# Altium schematic sheet SCH002 - VSC742x power supply & decoupling.SchDoc
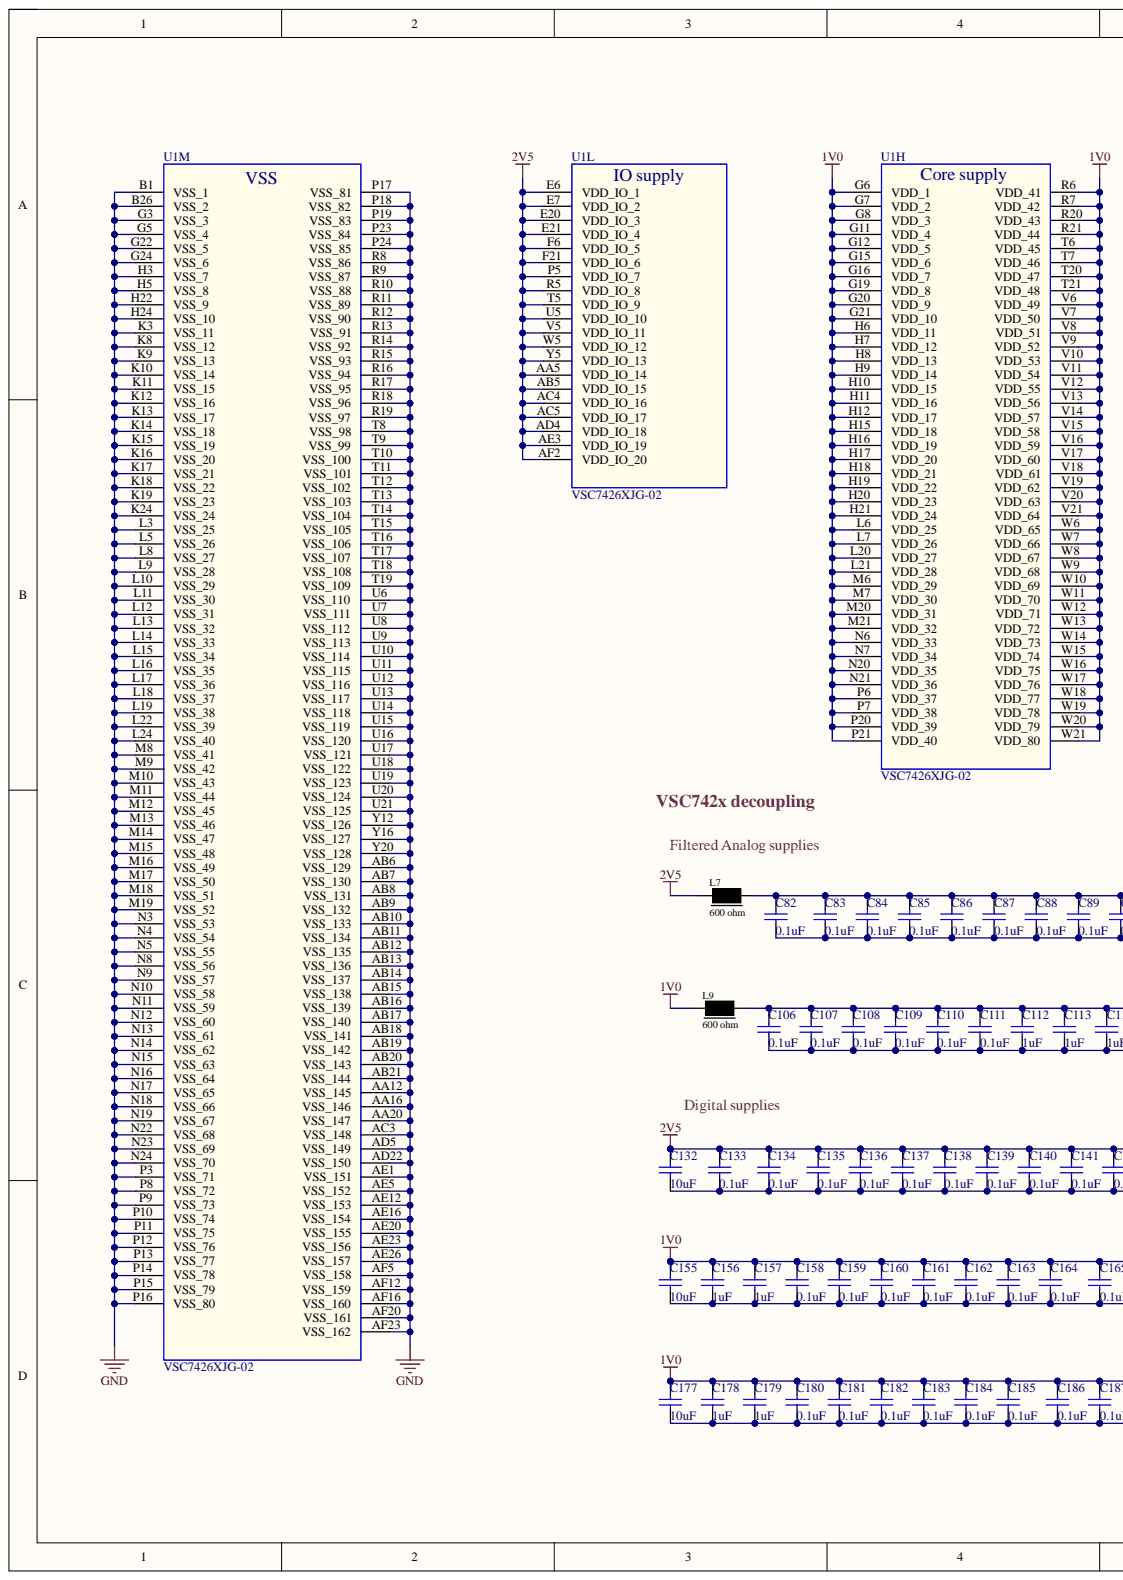
[diagram p0: SCH002 - VSC742x power supply & decoupling.SchDoc, left]
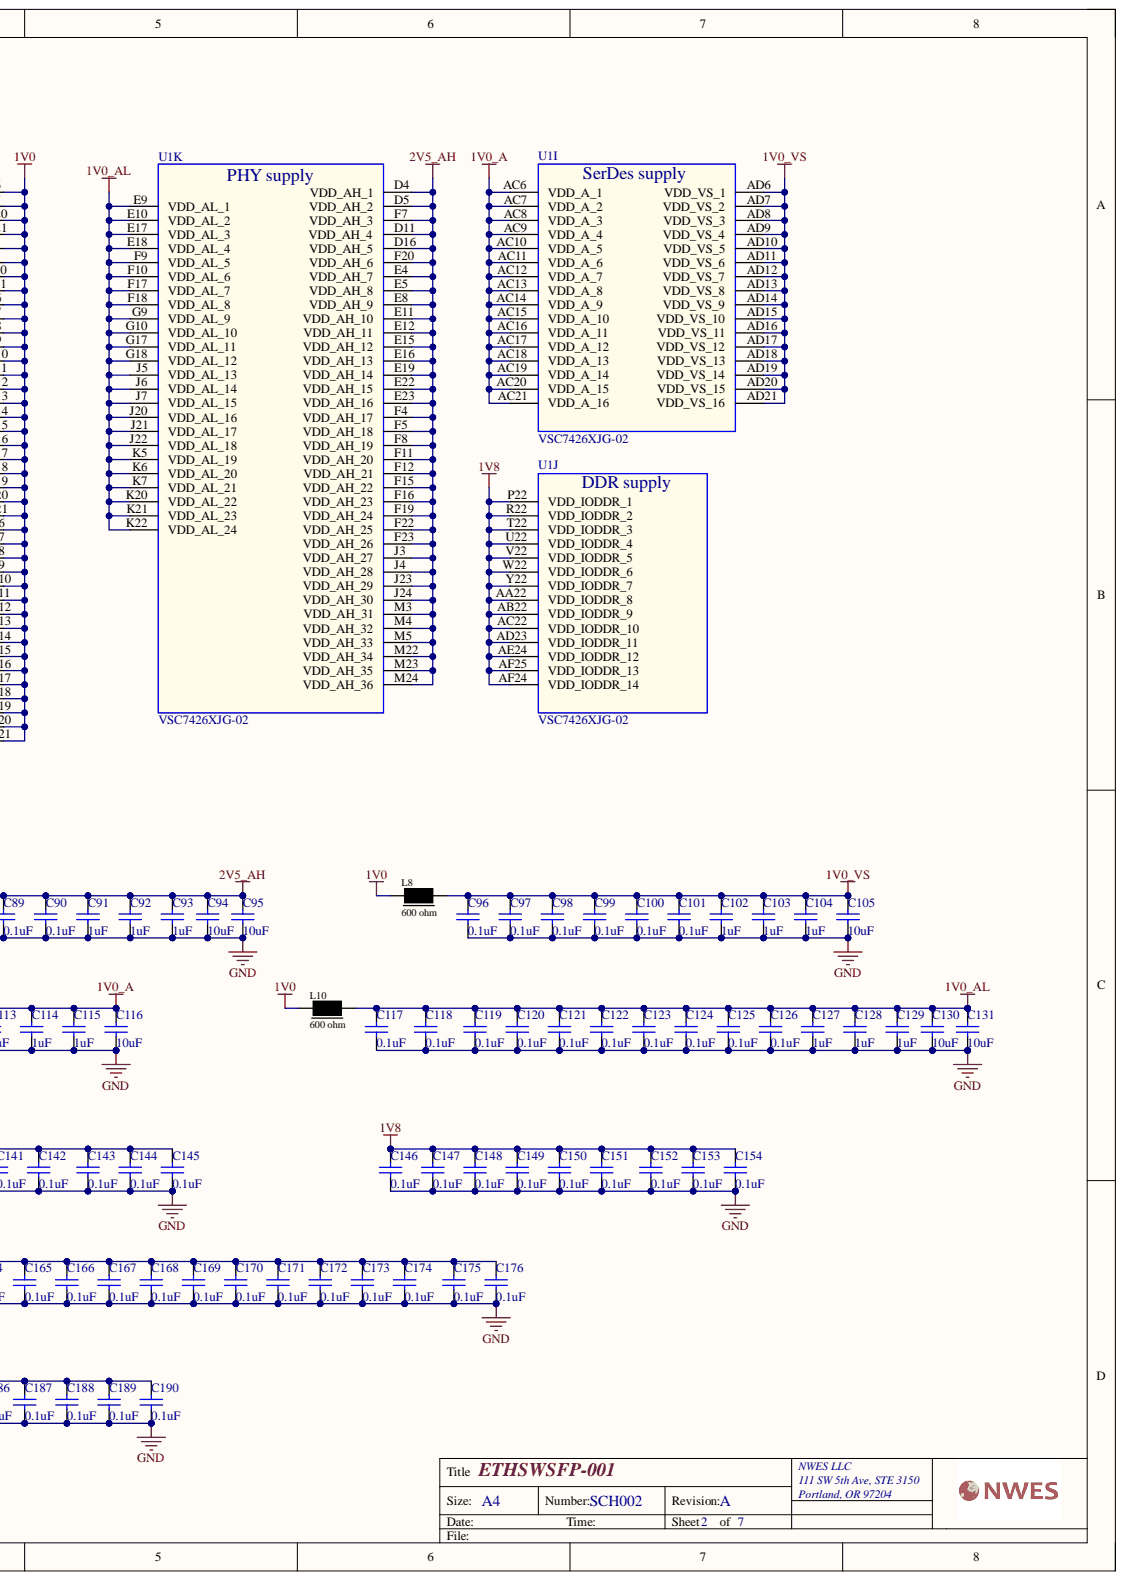
[diagram p1: SCH002 - VSC742x power supply & decoupling.SchDoc, right]

## Parts
C82  0.1uF  [CAPC1005X61USR]
C83  0.1uF  [CAPC1005X61USR]
C84  0.1uF  [CAPC1005X61USR]
C85  0.1uF  [CAPC1005X61USR]
C86  0.1uF  [CAPC1005X61USR]
C87  0.1uF  [CAPC1005X61USR]
C88  0.1uF  [CAPC1005X61USR]
C89  0.1uF  [CAPC1005X61USR]
C90  0.1uF  [CAPC1005X61USR]
C91  1uF  [CAPC1005X61USR]
C92  1uF  [CAPC1005X61USR]
C93  1uF  [CAPC1005X61USR]
C94  10uF  [CAPC1005X61USR]
C95  10uF  [CAPC1005X61USR]
C96  0.1uF  [CAPC1005X61USR]
C97  0.1uF  [CAPC1005X61USR]
C98  0.1uF  [CAPC1005X61USR]
C99  0.1uF  [CAPC1005X61USR]
C100  0.1uF  [CAPC1005X61USR]
C101  0.1uF  [CAPC1005X61USR]
C102  1uF  [CAPC1005X61USR]
C103  1uF  [CAPC1005X61USR]
C104  1uF  [CAPC1005X61USR]
C105  10uF  [CAPC1005X61USR]
C106  0.1uF  [CAPC1005X61USR]
C107  0.1uF  [CAPC1005X61USR]
C108  0.1uF  [CAPC1005X61USR]
C109  0.1uF  [CAPC1005X61USR]
C110  0.1uF  [CAPC1005X61USR]
C111  0.1uF  [CAPC1005X61USR]
C112  1uF  [CAPC1005X61USR]
C113  1uF  [CAPC1005X61USR]
C114  1uF  [CAPC1005X61USR]
C115  1uF  [CAPC1005X61USR]
C116  10uF  [CAPC1005X61USR]
C117  0.1uF  [CAPC1005X61USR]
C118  0.1uF  [CAPC1005X61USR]
C119  0.1uF  [CAPC1005X61USR]
C120  0.1uF  [CAPC1005X61USR]
C121  0.1uF  [CAPC1005X61USR]
C122  0.1uF  [CAPC1005X61USR]
C123  0.1uF  [CAPC1005X61USR]
C124  0.1uF  [CAPC1005X61USR]
C125  0.1uF  [CAPC1005X61USR]
C126  0.1uF  [CAPC1005X61USR]
C127  1uF  [CAPC1005X61USR]
C128  1uF  [CAPC1005X61USR]
C129  1uF  [CAPC1005X61USR]
C130  10uF  [CAPC1005X61USR]
C131  10uF  [CAPC1005X61USR]
C132  10uF  [CAPC1005X61USR]
C133  0.1uF  [CAPC1005X61USR]
C134  0.1uF  [CAPC1005X61USR]
C135  0.1uF  [CAPC1005X61USR]
C136  0.1uF  [CAPC1005X61USR]
C137  0.1uF  [CAPC1005X61USR]
C138  0.1uF  [CAPC1005X61USR]
C139  0.1uF  [CAPC1005X61USR]
C140  0.1uF  [CAPC1005X61USR]
C141  0.1uF  [CAPC1005X61USR]
C142  0.1uF  [CAPC1005X61USR]
C143  0.1uF  [CAPC1005X61USR]
C144  0.1uF  [CAPC1005X61USR]
C145  0.1uF  [CAPC1005X61USR]
C146  0.1uF  [CAPC1005X61USR]
C147  0.1uF  [CAPC1005X61USR]
C148  0.1uF  [CAPC1005X61USR]
C149  0.1uF  [CAPC1005X61USR]
C150  0.1uF  [CAPC1005X61USR]
C151  0.1uF  [CAPC1005X61USR]
C152  0.1uF  [CAPC1005X61USR]
C153  0.1uF  [CAPC1005X61USR]
C154  0.1uF  [CAPC1005X61USR]
C155  10uF  [CAPC1005X61USR]
C156  1uF  [CAPC1005X61USR]
C157  1uF  [CAPC1005X61USR]
C158  0.1uF  [CAPC1005X61USR]
C159  0.1uF  [CAPC1005X61USR]
C160  0.1uF  [CAPC1005X61USR]
C161  0.1uF  [CAPC1005X61USR]
C162  0.1uF  [CAPC1005X61USR]
C163  0.1uF  [CAPC1005X61USR]
C164  0.1uF  [CAPC1005X61USR]
C165  0.1uF  [CAPC1005X61USR]
C166  0.1uF  [CAPC1005X61USR]
C167  0.1uF  [CAPC1005X61USR]
C168  0.1uF  [CAPC1005X61USR]
C169  0.1uF  [CAPC1005X61USR]
C170  0.1uF  [CAPC1005X61USR]
C171  0.1uF  [CAPC1005X61USR]
C172  0.1uF  [CAPC1005X61USR]
C173  0.1uF  [CAPC1005X61USR]
C174  0.1uF  [CAPC1005X61USR]
C175  0.1uF  [CAPC1005X61USR]
C176  0.1uF  [CAPC1005X61USR]
C177  10uF  [CAPC1005X61USR]
C178  1uF  [CAPC1005X61USR]
C179  1uF  [CAPC1005X61USR]
C180  0.1uF  [CAPC1005X61USR]
C181  0.1uF  [CAPC1005X61USR]
C182  0.1uF  [CAPC1005X61USR]
C183  0.1uF  [CAPC1005X61USR]
C184  0.1uF  [CAPC1005X61USR]
C185  0.1uF  [CAPC1005X61USR]
C186  0.1uF  [CAPC1005X61USR]
C187  0.1uF  [CAPC1005X61USR]
C188  0.1uF  [CAPC1005X61USR]
C189  0.1uF  [CAPC1005X61USR]
C190  0.1uF  [CAPC1005X61USR]
L7  600 ohm  [0603_FB]
L8  600 ohm  [0603_FB]
L9  600 ohm  [0603_FB]
L10  600 ohm  [0603_FB]
U1  VSC7426XJG-02  [BGA672C100P26X26_2700X2700X244]  (parts H,I,J,K,L,M)

## Nets
1V0: C155.1 C156.2 C157.2 C158.1 C159.1 C160.1 C161.1 C162.1 C163.1 C164.1 C165.1 C166.1 C167.1 C168.1 C169.1 C170.1 C171.1 C172.1 C173.1 C174.1 C175.1 C176.1 C177.1 C178.2 C179.2 C180.1 C181.1 C182.1 C183.1 C184.1 C185.1 C186.1 C187.1 C188.1 C189.1 C190.1 L8.1 L9.1 L10.1 U1.G6(VDD_1) U1.G7(VDD_2) U1.G8(VDD_3) U1.G11(VDD_4) U1.G12(VDD_5) U1.G15(VDD_6) U1.G16(VDD_7) U1.G19(VDD_8) U1.G20(VDD_9) U1.G21(VDD_10) U1.H6(VDD_11) U1.H7(VDD_12) U1.H8(VDD_13) U1.H9(VDD_14) U1.H10(VDD_15) U1.H11(VDD_16) U1.H12(VDD_17) U1.H15(VDD_18) U1.H16(VDD_19) U1.H17(VDD_20) U1.H18(VDD_21) U1.H19(VDD_22) U1.H20(VDD_23) U1.H21(VDD_24) U1.L6(VDD_25) U1.L7(VDD_26) U1.L20(VDD_27) U1.L21(VDD_28) U1.M6(VDD_29) U1.M7(VDD_30) U1.M20(VDD_31) U1.M21(VDD_32) U1.N6(VDD_33) U1.N7(VDD_34) U1.N20(VDD_35) U1.N21(VDD_36) U1.P6(VDD_37) U1.P7(VDD_38) U1.P20(VDD_39) U1.P21(VDD_40) U1.R6(VDD_41) U1.R7(VDD_42) U1.R20(VDD_43) U1.R21(VDD_44) U1.T6(VDD_45) U1.T7(VDD_46) U1.T20(VDD_47) U1.T21(VDD_48) U1.V6(VDD_49) U1.V7(VDD_50) U1.V8(VDD_51) U1.V9(VDD_52) U1.V10(VDD_53) U1.V11(VDD_54) U1.V12(VDD_55) U1.V13(VDD_56) U1.V14(VDD_57) U1.V15(VDD_58) U1.V16(VDD_59) U1.V17(VDD_60) U1.V18(VDD_61) U1.V19(VDD_62) U1.V20(VDD_63) U1.V21(VDD_64) U1.W6(VDD_65) U1.W7(VDD_66) U1.W8(VDD_67) U1.W9(VDD_68) U1.W10(VDD_69) U1.W11(VDD_70) U1.W12(VDD_71) U1.W13(VDD_72) U1.W14(VDD_73) U1.W15(VDD_74) U1.W16(VDD_75) U1.W17(VDD_76) U1.W18(VDD_77) U1.W19(VDD_78) U1.W20(VDD_79) U1.W21(VDD_80)
1V0_A: C106.1 C107.1 C108.1 C109.1 C110.1 C111.1 C112.2 C113.2 C114.2 C115.2 C116.1 L9.2 U1.AC6(VDD_A_1) U1.AC7(VDD_A_2) U1.AC8(VDD_A_3) U1.AC9(VDD_A_4) U1.AC10(VDD_A_5) U1.AC11(VDD_A_6) U1.AC12(VDD_A_7) U1.AC13(VDD_A_8) U1.AC14(VDD_A_9) U1.AC15(VDD_A_10) U1.AC16(VDD_A_11) U1.AC17(VDD_A_12) U1.AC18(VDD_A_13) U1.AC19(VDD_A_14) U1.AC20(VDD_A_15) U1.AC21(VDD_A_16)
1V0_AL: C117.1 C118.1 C119.1 C120.1 C121.1 C122.1 C123.1 C124.1 C125.1 C126.1 C127.2 C128.2 C129.2 C130.1 C131.1 L10.2 U1.E9(VDD_AL_1) U1.E10(VDD_AL_2) U1.E17(VDD_AL_3) U1.E18(VDD_AL_4) U1.F9(VDD_AL_5) U1.F10(VDD_AL_6) U1.F17(VDD_AL_7) U1.F18(VDD_AL_8) U1.G9(VDD_AL_9) U1.G10(VDD_AL_10) U1.G17(VDD_AL_11) U1.G18(VDD_AL_12) U1.J5(VDD_AL_13) U1.J6(VDD_AL_14) U1.J7(VDD_AL_15) U1.J20(VDD_AL_16) U1.J21(VDD_AL_17) U1.J22(VDD_AL_18) U1.K5(VDD_AL_19) U1.K6(VDD_AL_20) U1.K7(VDD_AL_21) U1.K20(VDD_AL_22) U1.K21(VDD_AL_23) U1.K22(VDD_AL_24)
1V0_VS: C96.1 C97.1 C98.1 C99.1 C100.1 C101.1 C102.2 C103.2 C104.2 C105.1 L8.2 U1.AD6(VDD_VS_1) U1.AD7(VDD_VS_2) U1.AD8(VDD_VS_3) U1.AD9(VDD_VS_4) U1.AD10(VDD_VS_5) U1.AD11(VDD_VS_6) U1.AD12(VDD_VS_7) U1.AD13(VDD_VS_8) U1.AD14(VDD_VS_9) U1.AD15(VDD_VS_10) U1.AD16(VDD_VS_11) U1.AD17(VDD_VS_12) U1.AD18(VDD_VS_13) U1.AD19(VDD_VS_14) U1.AD20(VDD_VS_15) U1.AD21(VDD_VS_16)
1V8: C146.1 C147.1 C148.1 C149.1 C150.1 C151.1 C152.1 C153.1 C154.1 U1.AA22(VDD_IODDR_8) U1.AB22(VDD_IODDR_9) U1.AC22(VDD_IODDR_10) U1.AD23(VDD_IODDR_11) U1.AE24(VDD_IODDR_12) U1.AF24(VDD_IODDR_14) U1.AF25(VDD_IODDR_13) U1.P22(VDD_IODDR_1) U1.R22(VDD_IODDR_2) U1.T22(VDD_IODDR_3) U1.U22(VDD_IODDR_4) U1.V22(VDD_IODDR_5) U1.W22(VDD_IODDR_6) U1.Y22(VDD_IODDR_7)
2V5: C132.1 C133.1 C134.1 C135.1 C136.1 C137.1 C138.1 C139.1 C140.1 C141.1 C142.1 C143.1 C144.1 C145.1 L7.1 U1.AA5(VDD_IO_14) U1.AB5(VDD_IO_15) U1.AC4(VDD_IO_16) U1.AC5(VDD_IO_17) U1.AD4(VDD_IO_18) U1.AE3(VDD_IO_19) U1.AF2(VDD_IO_20) U1.E6(VDD_IO_1) U1.E7(VDD_IO_2) U1.E20(VDD_IO_3) U1.E21(VDD_IO_4) U1.F6(VDD_IO_5) U1.F21(VDD_IO_6) U1.P5(VDD_IO_7) U1.R5(VDD_IO_8) U1.T5(VDD_IO_9) U1.U5(VDD_IO_10) U1.V5(VDD_IO_11) U1.W5(VDD_IO_12) U1.Y5(VDD_IO_13)
2V5_AH: C82.1 C83.1 C84.1 C85.1 C86.1 C87.1 C88.1 C89.1 C90.1 C91.2 C92.2 C93.2 C94.1 C95.1 L7.2 U1.D4(VDD_AH_1) U1.D5(VDD_AH_2) U1.D11(VDD_AH_4) U1.D16(VDD_AH_5) U1.E4(VDD_AH_7) U1.E5(VDD_AH_8) U1.E8(VDD_AH_9) U1.E11(VDD_AH_10) U1.E12(VDD_AH_11) U1.E15(VDD_AH_12) U1.E16(VDD_AH_13) U1.E19(VDD_AH_14) U1.E22(VDD_AH_15) U1.E23(VDD_AH_16) U1.F4(VDD_AH_17) U1.F5(VDD_AH_18) U1.F7(VDD_AH_3) U1.F8(VDD_AH_19) U1.F11(VDD_AH_20) U1.F12(VDD_AH_21) U1.F15(VDD_AH_22) U1.F16(VDD_AH_23) U1.F19(VDD_AH_24) U1.F20(VDD_AH_6) U1.F22(VDD_AH_25) U1.F23(VDD_AH_26) U1.J3(VDD_AH_27) U1.J4(VDD_AH_28) U1.J23(VDD_AH_29) U1.J24(VDD_AH_30) U1.M3(VDD_AH_31) U1.M4(VDD_AH_32) U1.M5(VDD_AH_33) U1.M22(VDD_AH_34) U1.M23(VDD_AH_35) U1.M24(VDD_AH_36)
GND: C82.2 C83.2 C84.2 C85.2 C86.2 C87.2 C88.2 C89.2 C90.2 C91.1 C92.1 C93.1 C94.2 C95.2 C96.2 C97.2 C98.2 C99.2 C100.2 C101.2 C102.1 C103.1 C104.1 C105.2 C106.2 C107.2 C108.2 C109.2 C110.2 C111.2 C112.1 C113.1 C114.1 C115.1 C116.2 C117.2 C118.2 C119.2 C120.2 C121.2 C122.2 C123.2 C124.2 C125.2 C126.2 C127.1 C128.1 C129.1 C130.2 C131.2 C132.2 C133.2 C134.2 C135.2 C136.2 C137.2 C138.2 C139.2 C140.2 C141.2 C142.2 C143.2 C144.2 C145.2 C146.2 C147.2 C148.2 C149.2 C150.2 C151.2 C152.2 C153.2 C154.2 C155.2 C156.1 C157.1 C158.2 C159.2 C160.2 C161.2 C162.2 C163.2 C164.2 C165.2 C166.2 C167.2 C168.2 C169.2 C170.2 C171.2 C172.2 C173.2 C174.2 C175.2 C176.2 C177.2 C178.1 C179.1 C180.2 C181.2 C182.2 C183.2 C184.2 C185.2 C186.2 C187.2 C188.2 C189.2 C190.2 U1.AA12(VSS_145) U1.AA16(VSS_146) U1.AA20(VSS_147) U1.AB6(VSS_129) U1.AB7(VSS_130) U1.AB8(VSS_131) U1.AB9(VSS_132) U1.AB10(VSS_133) U1.AB11(VSS_134) U1.AB12(VSS_135) U1.AB13(VSS_136) U1.AB14(VSS_137) U1.AB15(VSS_138) U1.AB16(VSS_139) U1.AB17(VSS_140) U1.AB18(VSS_141) U1.AB19(VSS_142) U1.AB20(VSS_143) U1.AB21(VSS_144) U1.AC3(VSS_148) U1.AD5(VSS_149) U1.AD22(VSS_150) U1.AE1(VSS_151) U1.AE5(VSS_152) U1.AE12(VSS_153) U1.AE16(VSS_154) U1.AE20(VSS_155) U1.AE23(VSS_156) U1.AE26(VSS_157) U1.AF5(VSS_158) U1.AF12(VSS_159) U1.AF16(VSS_160) U1.AF20(VSS_161) U1.AF23(VSS_162) U1.B1(VSS_1) U1.B26(VSS_2) U1.G3(VSS_3) U1.G5(VSS_4) U1.G22(VSS_5) U1.G24(VSS_6) U1.H3(VSS_7) U1.H5(VSS_8) U1.H22(VSS_9) U1.H24(VSS_10) U1.K3(VSS_11) U1.K8(VSS_12) U1.K9(VSS_13) U1.K10(VSS_14) U1.K11(VSS_15) U1.K12(VSS_16) U1.K13(VSS_17) U1.K14(VSS_18) U1.K15(VSS_19) U1.K16(VSS_20) U1.K17(VSS_21) U1.K18(VSS_22) U1.K19(VSS_23) U1.K24(VSS_24) U1.L3(VSS_25) U1.L5(VSS_26) U1.L8(VSS_27) U1.L9(VSS_28) U1.L10(VSS_29) U1.L11(VSS_30) U1.L12(VSS_31) U1.L13(VSS_32) U1.L14(VSS_33) U1.L15(VSS_34) U1.L16(VSS_35) U1.L17(VSS_36) U1.L18(VSS_37) U1.L19(VSS_38) U1.L22(VSS_39) U1.L24(VSS_40) U1.M8(VSS_41) U1.M9(VSS_42) U1.M10(VSS_43) U1.M11(VSS_44) U1.M12(VSS_45) U1.M13(VSS_46) U1.M14(VSS_47) U1.M15(VSS_48) U1.M16(VSS_49) U1.M17(VSS_50) U1.M18(VSS_51) U1.M19(VSS_52) U1.N3(VSS_53) U1.N4(VSS_54) U1.N5(VSS_55) U1.N8(VSS_56) U1.N9(VSS_57) U1.N10(VSS_58) U1.N11(VSS_59) U1.N12(VSS_60) U1.N13(VSS_61) U1.N14(VSS_62) U1.N15(VSS_63) U1.N16(VSS_64) U1.N17(VSS_65) U1.N18(VSS_66) U1.N19(VSS_67) U1.N22(VSS_68) U1.N23(VSS_69) U1.N24(VSS_70) U1.P3(VSS_71) U1.P8(VSS_72) U1.P9(VSS_73) U1.P10(VSS_74) U1.P11(VSS_75) U1.P12(VSS_76) U1.P13(VSS_77) U1.P14(VSS_78) U1.P15(VSS_79) U1.P16(VSS_80) U1.P17(VSS_81) U1.P18(VSS_82) U1.P19(VSS_83) U1.P23(VSS_84) U1.P24(VSS_85) U1.R8(VSS_86) U1.R9(VSS_87) U1.R10(VSS_88) U1.R11(VSS_89) U1.R12(VSS_90) U1.R13(VSS_91) U1.R14(VSS_92) U1.R15(VSS_93) U1.R16(VSS_94) U1.R17(VSS_95) U1.R18(VSS_96) U1.R19(VSS_97) U1.T8(VSS_98) U1.T9(VSS_99) U1.T10(VSS_100) U1.T11(VSS_101) U1.T12(VSS_102) U1.T13(VSS_103) U1.T14(VSS_104) U1.T15(VSS_105) U1.T16(VSS_106) U1.T17(VSS_107) U1.T18(VSS_108) U1.T19(VSS_109) U1.U6(VSS_110) U1.U7(VSS_111) U1.U8(VSS_112) U1.U9(VSS_113) U1.U10(VSS_114) U1.U11(VSS_115) U1.U12(VSS_116) U1.U13(VSS_117) U1.U14(VSS_118) U1.U15(VSS_119) U1.U16(VSS_120) U1.U17(VSS_121) U1.U18(VSS_122) U1.U19(VSS_123) U1.U20(VSS_124) U1.U21(VSS_125) U1.Y12(VSS_126) U1.Y16(VSS_127) U1.Y20(VSS_128)

## Library data of the parts
C82-C90 C96-C101 C106-C111 C117-C126 C133-C154 C158-C176 C180-C190 = CAP CER 0.1UF 50V X7R 0402: Manufacturer: Murata Electronics; Manufacturer Part Number: GRM155R71H104KE14D; Component Kind: Standard; Description: CAP CER 0.1UF 50V X7R 0402; Package: 0402; Supplier 1: Digi-Key; Supplier Part Number 1: 490-10700-2-ND; value: 0.1uF; Library Name: 15-port switch V1.SCHLIB
C91-C93 C102-C104 C112-C115 C127-C129 C156 C157 C178 C179 = CAP CER 1UF 25V X5R 0402: Manufacturer: Murata Electronics; Manufacturer Part Number: GRM155R61E105MA12D; Component Kind: Standard; Description: CAP CER 1UF 25V X5R 0402; Package: 0402; Supplier 1: Digi-Key; Supplier Part Number 1: 490-10018-1-ND; value: 1uF; Library Name: 15-port switch V1.SCHLIB
C94 C95 C105 C116 C130-C132 C155 C177 = CAP CER 10UF 10V X5R 0402: Manufacturer: Samsung Electro-Mechanics; Manufacturer Part Number: CL05A106MP8NUB8; Component Kind: Standard; Description: CAP CER 10UF 10V X5R 0402; Package: 0402; Supplier 1: Digi-Key; Supplier Part Number 1: 1276-6830-1-ND; value: 10uF; Library Name: 15-port switch V1.SCHLIB
L7-L10 = FERRITE BEAD 600 OHM 0603 1LN: Manufacturer: Würth Elektronik; Manufacturer Part Number: 742863160; Component Kind: Standard; Description: FERRITE BEAD 600 OHM 0603 1LN; Package: 0603; Supplier 1: Digi-Key; Supplier Part Number 1: 732-2389-1-ND; Value: 600 ohm; Library Name: 15-port switch V1.SCHLIB
U1 = VSC7426XJG-02: IC TELECOM INTERFACE 672BGA; Manufacturer: Microchip Technology; Geometry.Height: 2.44mm; Component Kind: Standard; Manufacturer Part Number: VSC7426XJG-02; Supplier Part Number 1: VSC7426XJG-02-ND; Library Name: 15-port switch V1.SCHLIB
